# Altium schematic sheet Sheet_hackheld.SchDoc
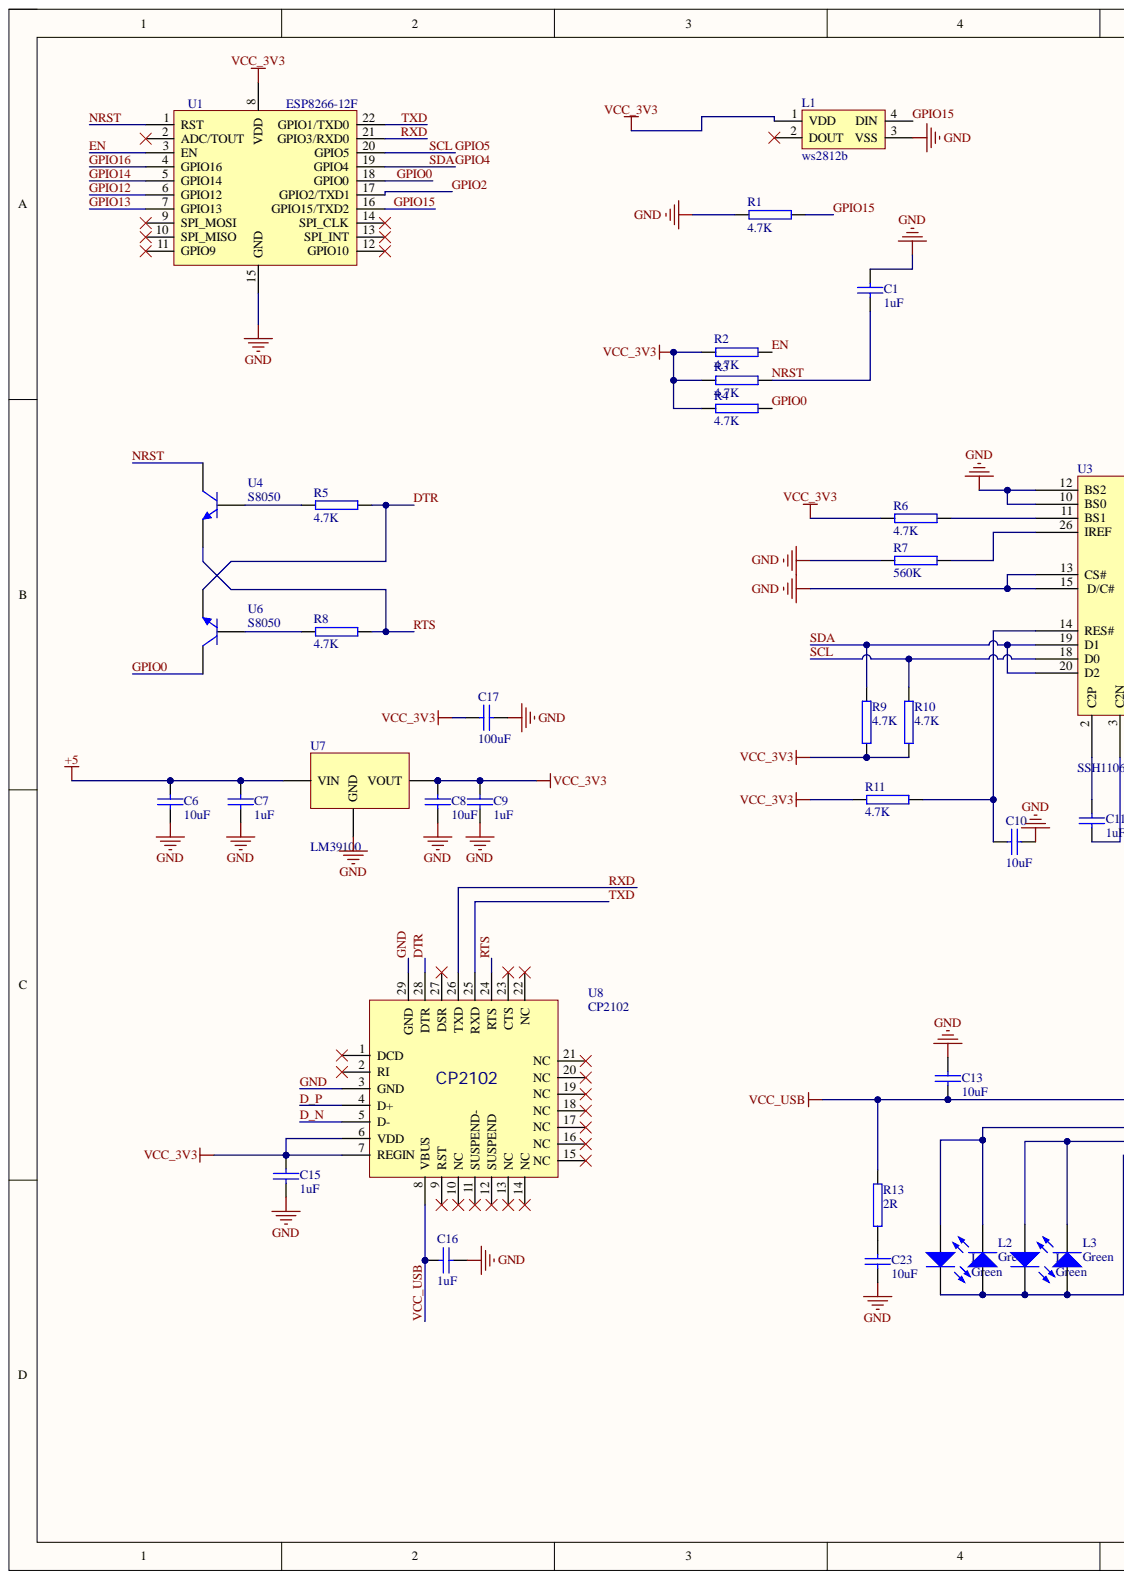
[diagram p0: Sheet_hackheld.SchDoc, left]
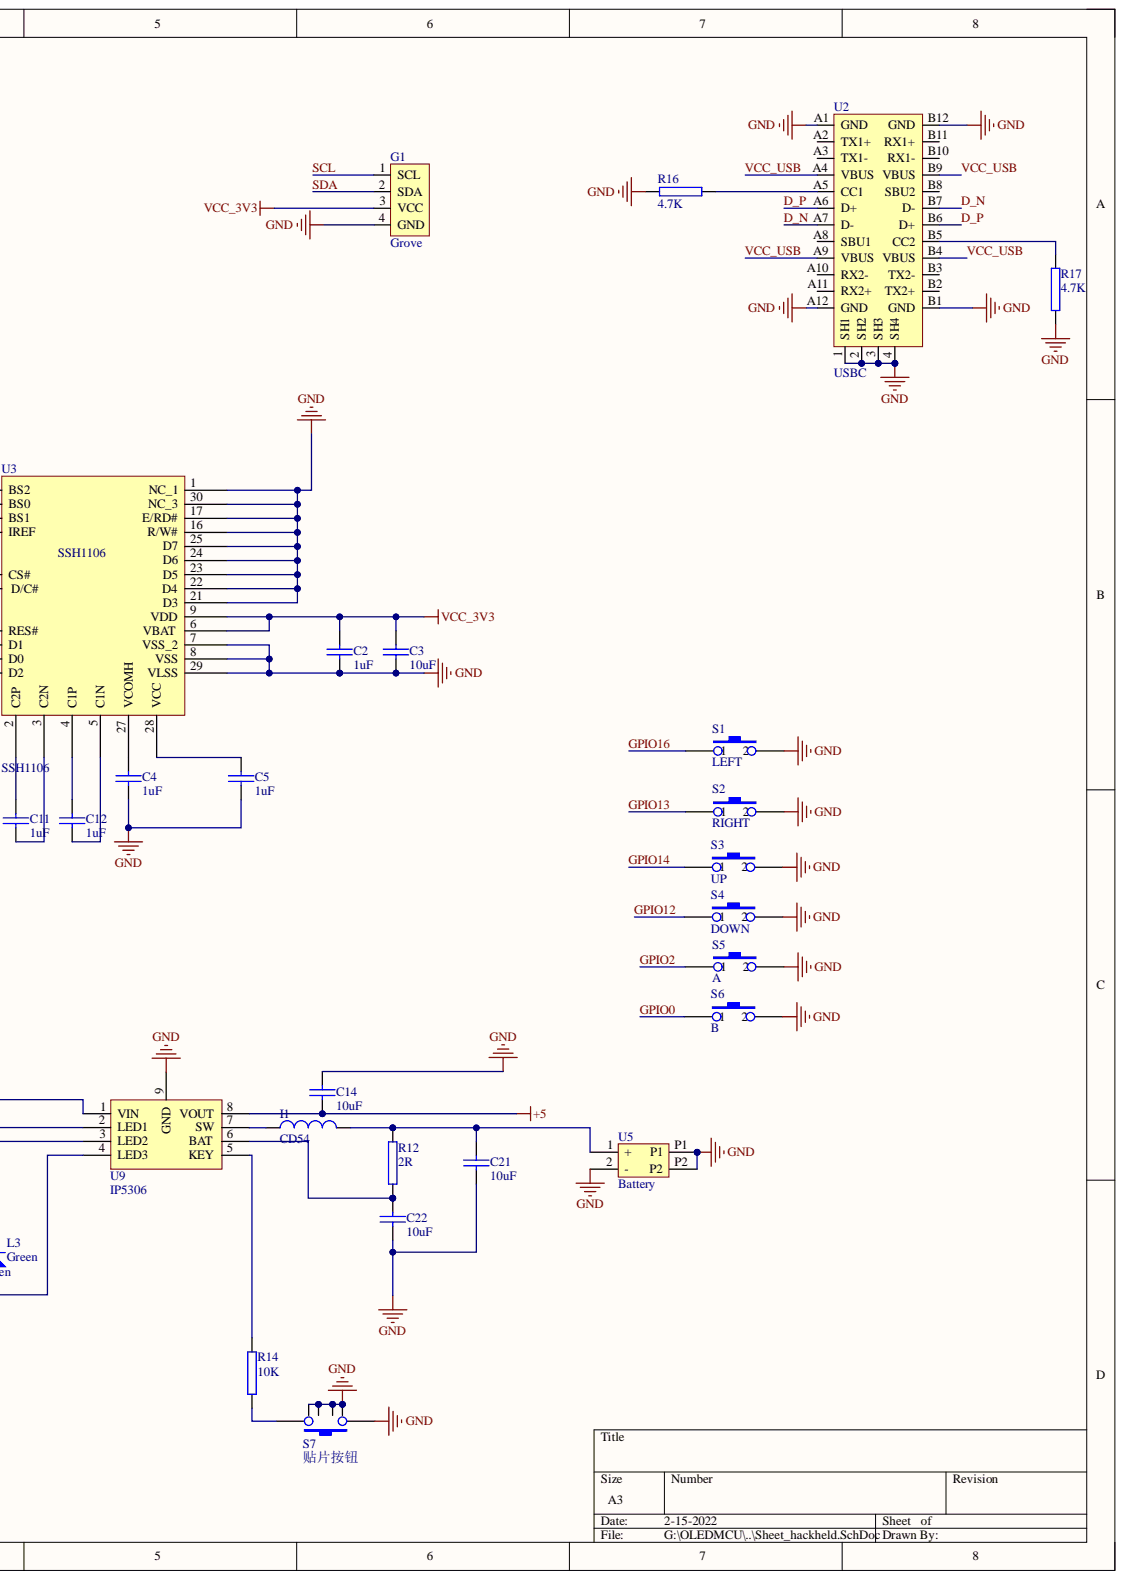
[diagram p1: Sheet_hackheld.SchDoc, right]

## Parts
C1  1uF  [C0603]
C2  1uF  [C0603]
C3  10uF  [C0603]
C4  1uF  [C0603]
C5  1uF  [C0603]
C6  10uF  [C0603]
C7  1uF  [C0603]
C8  10uF  [C0603]
C9  1uF  [C0603]
C10  10uF  [C0603]
C11  1uF  [C0603]
C12  1uF  [C0603]
C13  10uF  [C0603]
C14  10uF  [C0603]
C15  1uF  [C0603]
C16  1uF  [C0603]
C17  100uF  [C3528]
C21  10uF  [C0603]
C22  10uF  [C0603]
C23  10uF  [C0603]
G1  Grove  [grove]
I1  CD54  [INTRODUCTOR_1R0]
L1  ws2812b  [ws2812b]
L2  Green  [LED]
L3  Green  [LED]
L4  Green  [LED]
L5  Green  [LED]
R1  4.7K  [R0603]
R2  4.7K  [R0603]
R3  4.7K  [R0603]
R4  4.7K  [R0603]
R5  4.7K  [R0603]
R6  4.7K  [R0603]
R7  560K  [R0603]
R8  4.7K  [R0603]
R9  4.7K  [R0603]
R10  4.7K  [R0603]
R11  4.7K  [R0603]
R12  2R  [R0603]
R13  2R  [R0603]
R14  10K  [R0603]
R16  4.7K  [R0603]
R17  4.7K  [R0603]
S1  LEFT  [Switch_power]
S2  RIGHT  [Switch_power]
S3  UP  [Switch_power]
S4  DOWN  [Switch_power]
S5  A  [Switch_power]
S6  B  [Switch_power]
S7  贴片按钮  [SWITCH_SIDE]
U1  ESP8266-12F  [esp8266-12f]
U2  USBC  [USB-C_TOP]
U3  SSH1106  [SSH1106]
U4  S8050  [s8050]
U5  Battery  [S2B-PH-SM4-TB]
U6  S8050  [s8050]
U7  LM39100  [LM39100]
U8  CP2102  [cp2102]
U9  IP5306  [IP5306]

## Nets
+5: C6.1 C7.1 C14.2 U7.1 U9.8(VOUT)
VCC_3V3: C2.1 C3.1 C8.1 C9.1 C15.1 C17.1 G1.3(VCC) L1.1(VDD) R2.1 R3.1 R4.1 R6.1 R9.1 R10.1 R11.1 U1.8(VDD) U3.6(VBAT) U3.9(VDD) U7.3 U8.6(VDD) U8.7(REGIN)
D_N: U2.A7(D-) U2.B7(D-) U8.5(D-)
D_P: U2.A6(D+) U2.B6(D+) U8.4(D+)
DTR: R5.2 U6.2 U8.28(DTR)
EN: R2.2 U1.3(EN)
GND: C1.2 C2.2 C3.2 C4.2 C5.2 C6.2 C7.2 C8.2 C9.2 C10.2 C13.1 C14.1 C15.2 C16.2 C17.2 C21.2 C22.2 C23.2 G1.4(GND) L1.3(VSS) R1.1 R7.1 R16.1 R17.1 S1.2 S2.2 S3.2 S4.2 S5.2 S6.2 S7.1 S7.foot1 S7.foot2 S7.S1 S7.S2 U1.15(GND) U2.1(SH1) U2.2(SH2) U2.3(SH3) U2.4(SH4) U2.A1(GND) U2.A12(GND) U2.B1(GND) U2.B12(GND) U3.1(NC_1) U3.7(VSS_2) U3.8(VSS) U3.10(BS0) U3.12(BS2) U3.13(CS#) U3.15(D/C#) U3.16(R/W#) U3.17(E/RD#) U3.21(D3) U3.22(D4) U3.23(D5) U3.24(D6) U3.25(D7) U3.29(VLSS) U3.30(NC_3) U5.2(-) U5.P1 U5.P2 U7.2 U8.3(GND) U8.29(GND) U9.9(GND)
GPIO0: R4.2 S6.1 U1.18(GPIO0) U6.3
GPIO2: S5.1 U1.17(GPIO2/TXD1)
GPIO4: G1.2(SDA) R9.2 U1.19(GPIO4) U3.19(D1) U3.20(D2)
GPIO5: G1.1(SCL) R10.2 U1.20(GPIO5) U3.18(D0)
GPIO12: S4.1 U1.6(GPIO12)
GPIO13: S2.1 U1.7(GPIO13)
GPIO14: S3.1 U1.5(GPIO14)
GPIO15: L1.4(DIN) R1.2 U1.16(GPIO15/TXD2)
GPIO16: S1.1 U1.4(GPIO16)
NRST: C1.1 R3.2 U1.1(RST) U4.3
RTS: R8.2 U4.2 U8.24(RTS)
RXD: U1.21(GPIO3/RXD0) U8.26(TXD)
TXD: U1.22(GPIO1/TXD0) U8.25(RXD)
VCC_USB: C13.2 C16.1 R13.2 U2.A4(VBUS) U2.A9(VBUS) U2.B4(VBUS) U2.B9(VBUS) U8.8(VBUS) U9.1(VIN)
NetC4_1: C4.1 U3.27(VCOMH)
NetC5_1: C5.1 U3.28(VCC)
NetC10_1: C10.1 R11.2 U3.14(RES#)
NetC11_1: C11.1 U3.2(C2P)
NetC11_2: C11.2 U3.3(C2N)
NetC12_1: C12.1 U3.4(C1P)
NetC12_2: C12.2 U3.5(C1N)
NetC21_1: C21.1 I1.2 R12.2 U5.1(+)
NetC22_1: C22.1 R12.1 U9.6(BAT)
NetC23_1: C23.1 R13.1
NetI1_1: I1.1 U9.7(SW)
NetL2_1: L2.1 L4.2 U9.2(LED1)
NetL2_2: L2.2 L3.2 L4.1 L5.1 U9.4(LED3)
NetL3_1: L3.1 L5.2 U9.3(LED2)
NetR5_1: R5.1 U4.1
NetR6_2: R6.2 U3.11(BS1)
NetR7_2: R7.2 U3.26(IREF)
NetR8_1: R8.1 U6.1
NetR14_1: R14.1 S7.2
NetR14_2: R14.2 U9.5(KEY)
NetR16_2: R16.2 U2.A5(CC1)
NetR17_2: R17.2 U2.B5(CC2)

Not connected: L1 2(DOUT); U1 2(ADC/TOUT) 9(SPI_MOSI) 10(SPI_MISO) 11(GPIO9) 12(GPIO10) 13(SPI_INT) 14(SPI_CLK); U2 A2(TX1+) A3(TX1-) A8(SBU1) A10(RX2-) A11(RX2+) B2(TX2+) B3(TX2-) B8(SBU2) B10(RX1-) B11(RX1+); U8 1(DCD) 2(RI) 9(RST) 10(NC) 11(SUSPEND-) 12(SUSPEND) 13(NC) 14(NC) 15(NC) 16(NC) 17(NC) 18(NC) 19(NC) 20(NC) 21(NC) 22(NC) 23(CTS) 27(DSR)

## Library data of the parts
C1-C17 C21-C23 = C0603: 贴片电容; Component Kind: Standard; Library Name: Schlib_OLEDMCU.SchLib
G1 = Grove: Grove Connector; Component Kind: Standard; Library Name: Schlib_OLEDMCU.SchLib
I1 = Inductor_1R0: 大功率电感; Component Kind: Standard; Library Name: Schlib_OLEDMCU.SchLib
L1 = ws2812b: RGB灯珠; Component Kind: Standard; Library Name: Schlib_OLEDMCU.SchLib
L2-L5 = LED: 0603 led; Component Kind: Standard; Library Name: Schlib_OLEDMCU.SchLib
R1-R14 R16 R17 = R0603: 贴片电阻; Component Kind: Standard; Library Name: Schlib_OLEDMCU.SchLib
S1-S6 = Switch_power: 金属开关; Component Kind: Standard; Library Name: Schlib_OLEDMCU.SchLib
S7 = Switch_side: 3*6*3.5mm侧卧开关; Component Kind: Standard; Library Name: Schlib_OLEDMCU.SchLib
U1 = ESP8266-12F: WiFi芯片; Component Kind: Standard; Library Name: Schlib_OLEDMCU.SchLib
U2 = USB-C_TOP: Type-C; Component Kind: Standard; Library Name: Schlib_OLEDMCU.SchLib
U3 = SSH1106: 1.3寸OLED; Component Kind: Standard; Library Name: Schlib_OLEDMCU.SchLib
U4 U6 = S8050: 三极管; Component Kind: Standard; Library Name: Schlib_OLEDMCU.SchLib
U5 = S2B-PH-SM4-TB: 贴片2.0mm底座; Component Kind: Standard; Library Name: Schlib_OLEDMCU.SchLib
U7 = LM39100: 3.3V低压差稳压; Component Kind: Standard; Library Name: Schlib_OLEDMCU.SchLib
U8 = CP2102: USB转串口芯片; Component Kind: Standard; Library Name: Schlib_OLEDMCU.SchLib
U9 = IP5306: 电源芯片; Component Kind: Standard; Library Name: Schlib_OLEDMCU.SchLib
